# Altium schematic sheet Sheet1.SchDoc
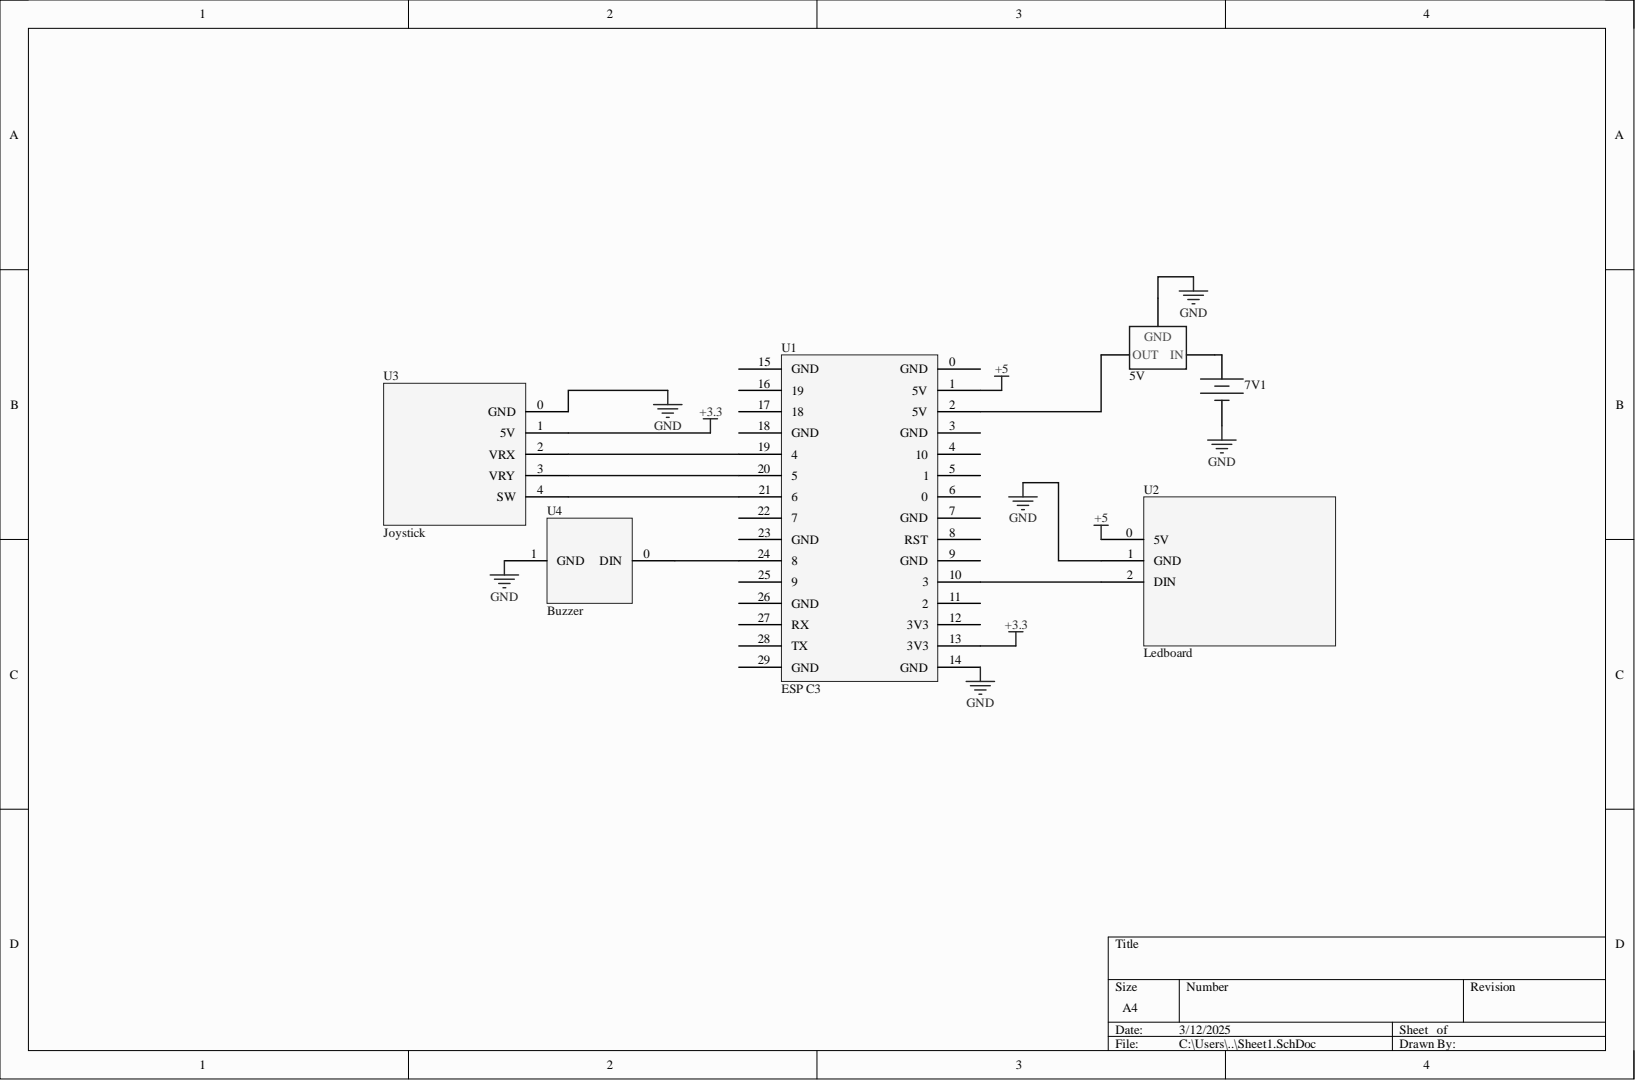
[diagram p0: Sheet1.SchDoc]

## Parts
5V  Positive Fixed Voltage regulator  (value not drawn)
7V1  Constant Voltage Source  (value not drawn)
U1  ESP C3
U2  Ledboard
U3  Joystick
U4  Buzzer

## Nets
+3.3: U1.13(3V3) U3.1(5V)
+5: U1.1(5V) U2.0
GND: 5V.2 7V1.2 U1.14(GND) U2.1 U3.0(GND) U4.1
Net5V_1: 5V.1 7V1.1
Net5V_3: 5V.3 U1.2(5V)
NetU1_10: U1.10 U2.2
NetU1_19: U1.19 U3.2(VRX)
NetU1_20: U1.20 U3.3(VRY)
NetU1_21: U1.21 U3.4(SW)
NetU1_24: U1.24 U4.0

Not connected: U1 0(GND) 3(GND) 4 5 6 7(GND) 8(RST) 9(GND) 11 12(3V3) 15(GND) 16 17 18(GND) 22 23(GND) 25 26(GND) 27(RX) 28(TX) 29(GND)

## Library data of the parts
5V = Linear Voltage Regulator (Fixed): Av_feedback: 1665; R1_Value: 1020; SimulationGenericComponent: True; Component Kind: Standard; Description: Positive Fixed Voltage regulator; Library Name: Simulation Generic Components.IntLib; Simulation: LM7805
7V1 = Battery: SimulationGenericComponent: True; Component Kind: Standard; Description: Constant Voltage Source; Library Name: Simulation Generic Components.IntLib; Simulation: Vconst
U1 = ESP C3: Component Kind: Standard; Library Name: Schlib1.SchLib
U2 = Ledboard: Component Kind: Standard; Library Name: Schlib1.SchLib
U3 = Joystick: Component Kind: Standard; Library Name: Schlib1.SchLib
U4 = Buzzer: Component Kind: Standard; Library Name: Schlib1.SchLib
